# Altium schematic sheet BRAINS.SchDoc(BRAINS)
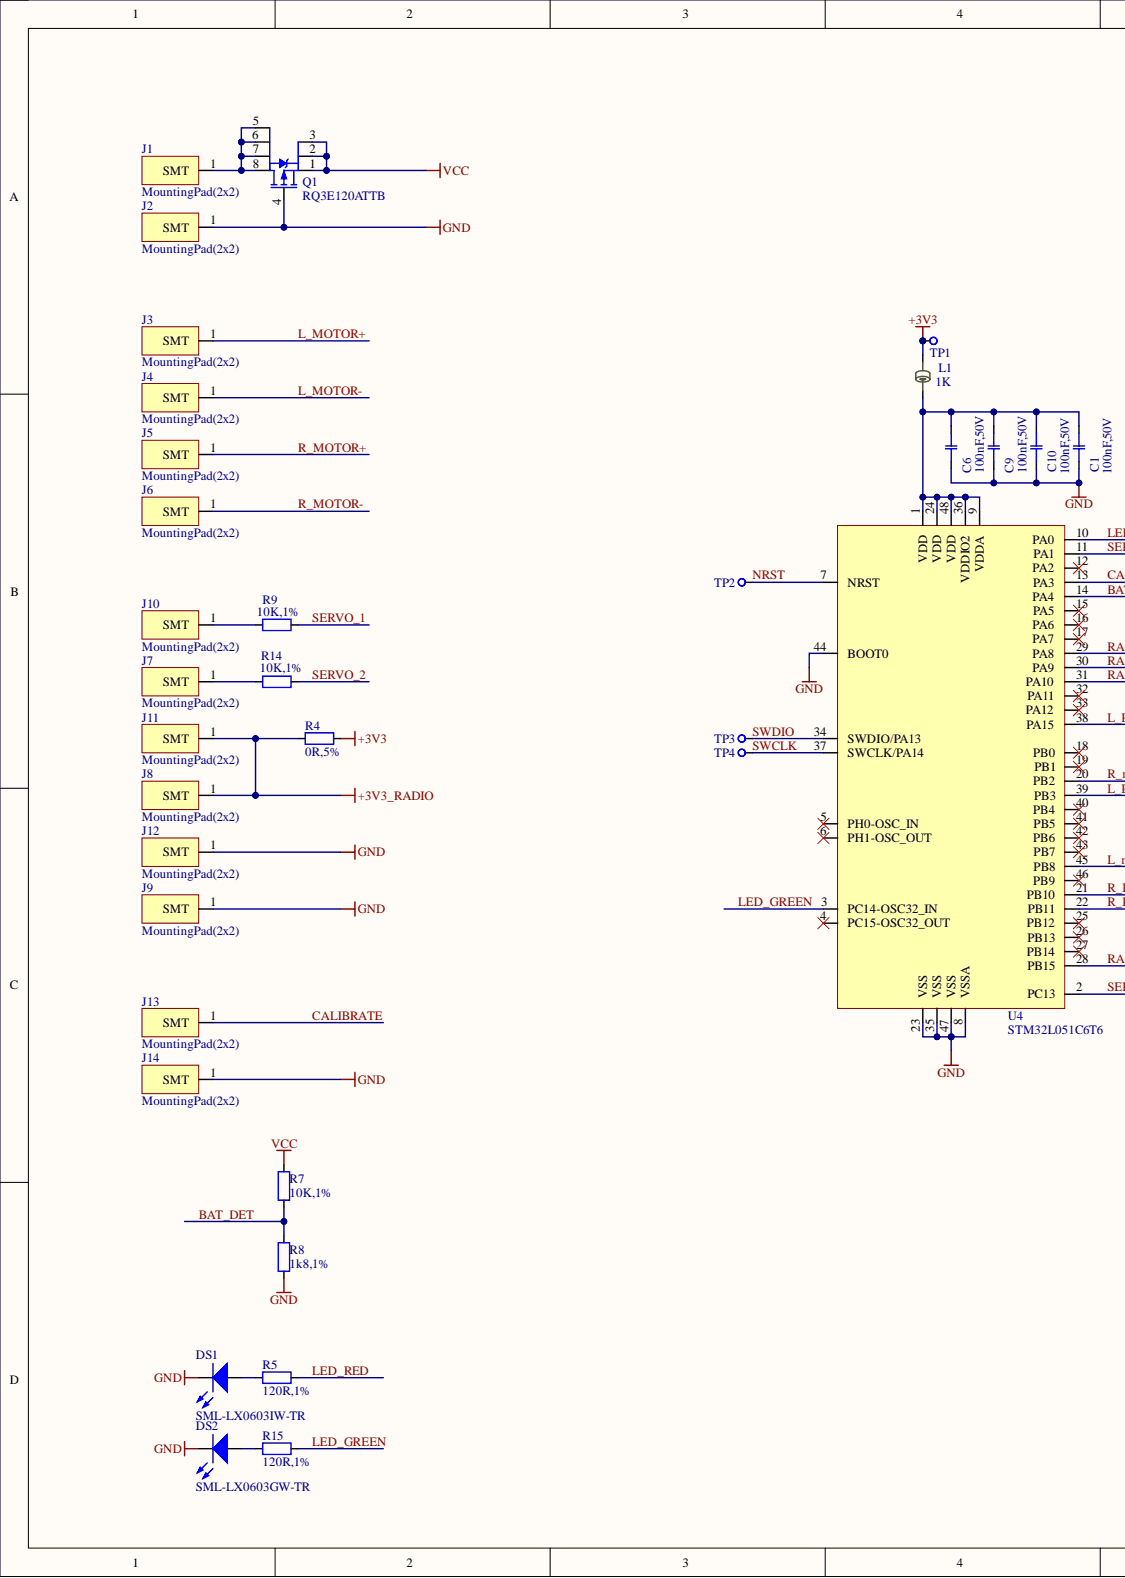
[diagram p0: BRAINS.SchDoc, left]
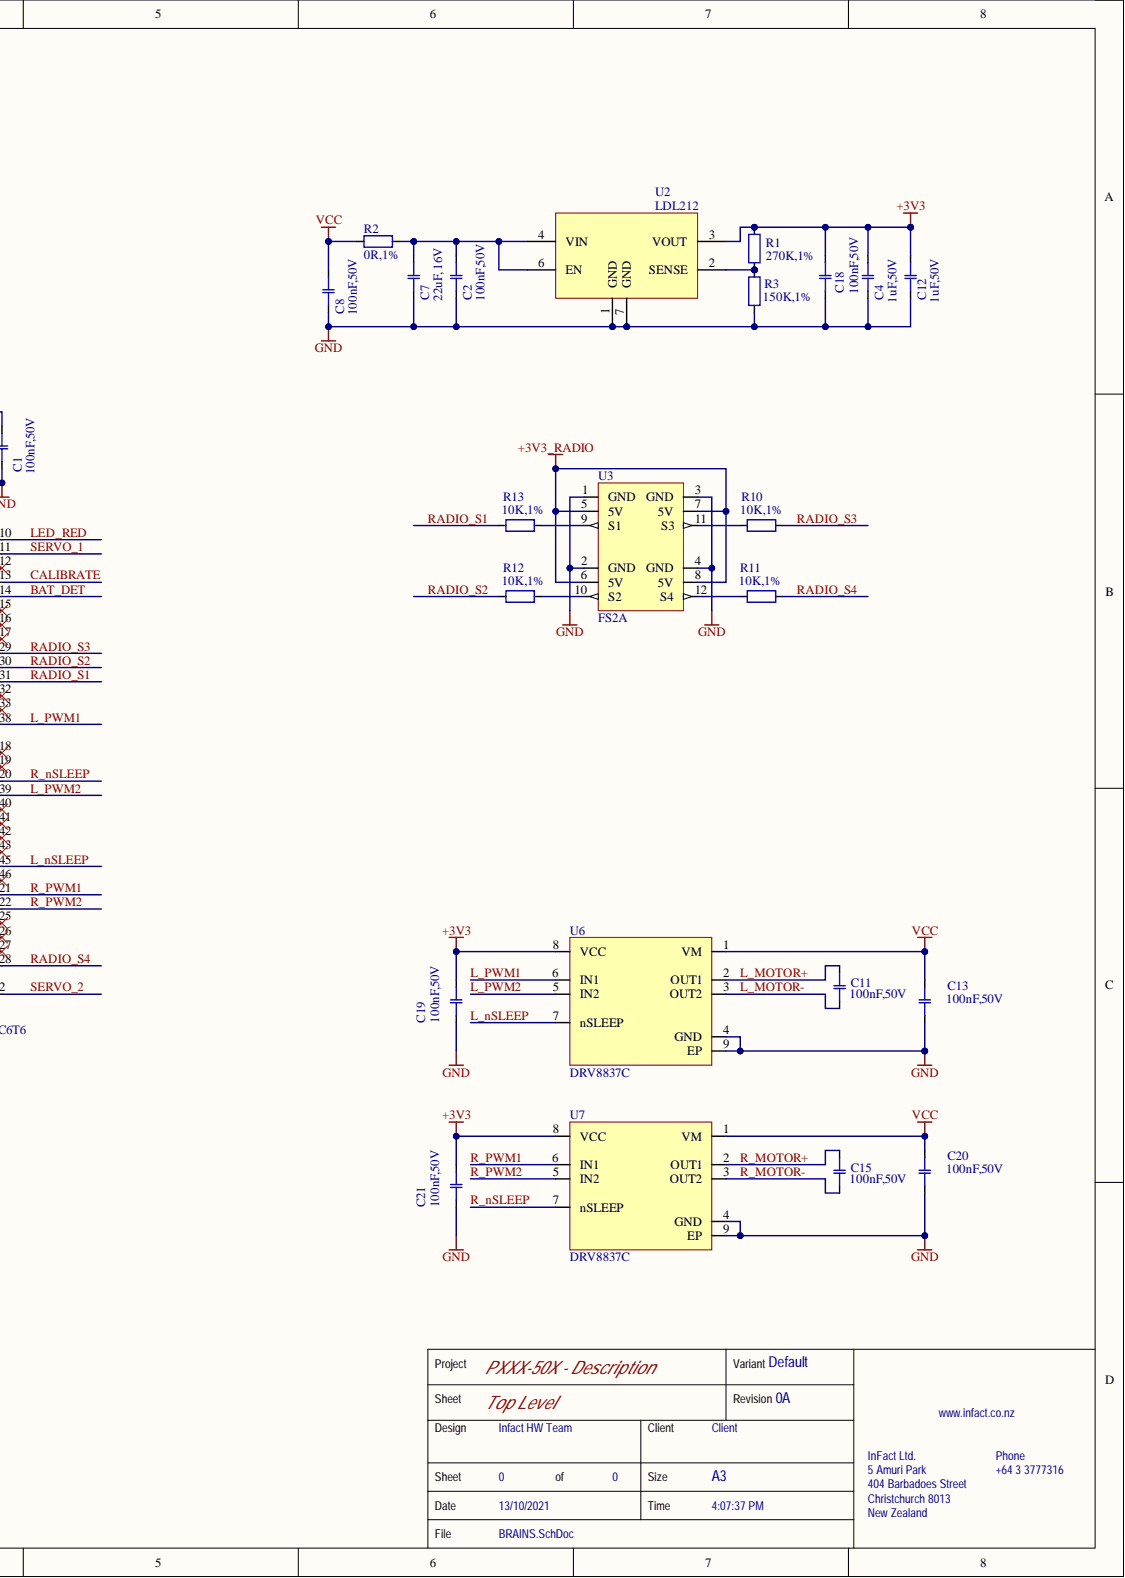
[diagram p1: BRAINS.SchDoc, right]

## Parts
C1  100nF,50V  [CAPC1005X05N_0402]
C2  100nF,50V  [CAPC1005X05N_0402]
C4  1uF,50V  [CAPC1005X05N_0402]
C6  100nF,50V  [CAPC1005X05N_0402]
C7  22uF, 16V  [CAPC2012X12N_0805]
C8  100nF,50V  [CAPC1005X05N_0402]
C9  100nF,50V  [CAPC1005X05N_0402]
C10  100nF,50V  [CAPC1005X05N_0402]
C11  100nF,50V  [CAPC1005X05N_0402]
C12  1uF,50V  [CAPC1005X05N_0402]
C13  100nF,50V  [CAPC1005X05N_0402]
C15  100nF,50V  [CAPC1005X05N_0402]
C18  100nF,50V  [CAPC1005X05N_0402]
C19  100nF,50V  [CAPC1005X05N_0402]
C20  100nF,50V  [CAPC1005X05N_0402]
C21  100nF,50V  [CAPC1005X05N_0402]
DS1  SML-LX0603IW-TR  [LED_SML-LX0603]
DS2  SML-LX0603GW-TR  [LED_SML-LX0603]
J1  MountingPad(2x2)  [MountingPad(2x2)]
J2  MountingPad(2x2)  [MountingPad(2x2)]
J3  MountingPad(2x2)  [MountingPad(2x2)]
J4  MountingPad(2x2)  [MountingPad(2x2)]
J5  MountingPad(2x2)  [MountingPad(2x2)]
J6  MountingPad(2x2)  [MountingPad(2x2)]
J7  MountingPad(2x2)  [MountingPad(2x2)]
J8  MountingPad(2x2)  [MountingPad(2x2)]
J9  MountingPad(2x2)  [MountingPad(2x2)]
J10  MountingPad(2x2)  [MountingPad(2x2)]
J11  MountingPad(2x2)  [MountingPad(2x2)]
J12  MountingPad(2x2)  [MountingPad(2x2)]
J13  MountingPad(2x2)  [MountingPad(2x2)]
J14  MountingPad(2x2)  [MountingPad(2x2)]
L1  1K  [INDC1005X04N_0402]
Q1  RQ3E120ATTB  [ROHM-HSMT8]
R1  270K,1%  [RESC1005X04N_0402]
R2  0R,1%  [RESC1005X04N_0402]
R3  150K,1%  [RESC1005X04N_0402]
R4  0R,5%  [RESC1608X06N_0603]
R5  120R,1%  [RESC1005X04N_0402]
R7  10K,1%  [RESC1005X04N_0402]
R8  1k8,1%  [RESC1005X04N_0402]
R9  10K,1%  [RESC1005X04N_0402]
R10  10K,1%  [RESC1005X04N_0402]
R11  10K,1%  [RESC1005X04N_0402]
R12  10K,1%  [RESC1005X04N_0402]
R13  10K,1%  [RESC1005X04N_0402]
R14  10K,1%  [RESC1005X04N_0402]
R15  120R,1%  [RESC1005X04N_0402]
TP1  TP_SMT  [TP_100]  (value not drawn)
TP2  TP_SMT  [TP_100]  (value not drawn)
TP3  TP_SMT  [TP_100]  (value not drawn)
TP4  TP_SMT  [TP_100]  (value not drawn)
U2  LDL212  [DFN50P200X200X80-8N_PWSON6]
U3  FS2A  [FS2A]
U4  STM32L051C6T6  [TSQFP50P900X900X160-48N_48LQFP]
U6  DRV8837C  [DFN50P200X200X80-8N]
U7  DRV8837C  [DFN50P200X200X80-8N]

## Nets
+3V3: C4.2 C12.2 C18.2 C19.2 C21.2 L1.2 R1.2 R4.2 TP1.1 U2.3(VOUT) U6.8(VCC) U7.8(VCC)
+3V3_RADIO: J8.1 J11.1 R4.1 U3.5(5V) U3.6(5V) U3.7(5V) U3.8(5V)
GND: C1.1 C2.1 C4.1 C6.1 C7.1 C8.1 C9.1 C10.1 C12.1 C13.1 C18.1 C19.1 C20.1 C21.1 DS1.1 DS2.1 J2.1 J9.1 J12.1 J14.1 Q1.4 R3.1 R8.2 U2.1(GND) U2.7(GND) U3.1(GND) U3.2(GND) U3.3(GND) U3.4(GND) U4.8(VSSA) U4.23(VSS) U4.35(VSS) U4.44(BOOT0) U4.47(VSS) U6.4(GND) U6.9(EP) U7.4(GND) U7.9(EP)
VCC: C8.2 C13.2 C20.2 Q1.1 Q1.2 Q1.3 R2.1 R7.1 U6.1(VM) U7.1(VM)
BAT_DET: R7.2 R8.1 U4.14(PA4)
CALIBRATE: J13.1 U4.13(PA3)
L_MOTOR+: C11.2 J3.1 U6.2(OUT1)
L_MOTOR-: C11.1 J4.1 U6.3(OUT2)
L_nSLEEP: U4.45(PB8) U6.7(nSLEEP)
L_PWM1: U4.38(PA15) U6.6(IN1)
L_PWM2: U4.39(PB3) U6.5(IN2)
LED_GREEN: R15.2 U4.3(PC14-OSC32_IN)
LED_RED: R5.2 U4.10(PA0)
NRST: TP2.1 U4.7(NRST)
R_MOTOR+: C15.2 J5.1 U7.2(OUT1)
R_MOTOR-: C15.1 J6.1 U7.3(OUT2)
R_nSLEEP: U4.20(PB2) U7.7(nSLEEP)
R_PWM1: U4.21(PB10) U7.6(IN1)
R_PWM2: U4.22(PB11) U7.5(IN2)
RADIO_S1: R13.2 U4.31(PA10)
RADIO_S2: R12.2 U4.30(PA9)
RADIO_S3: R10.1 U4.29(PA8)
RADIO_S4: R11.1 U4.28(PB15)
SERVO_1: R9.1 U4.11(PA1)
SERVO_2: R14.1 U4.2(PC13)
SWCLK: TP4.1 U4.37(SWCLK/PA14)
SWDIO: TP3.1 U4.34(SWDIO/PA13)
NetC1_2: C1.2 C6.2 C9.2 C10.2 L1.1 U4.1(VDD) U4.9(VDDA) U4.24(VDD) U4.36(VDDIO2) U4.48(VDD)
NetC2_2: C2.2 C7.2 R2.2 U2.4(VIN) U2.6(EN)
NetDS1_2: DS1.2 R5.1
NetDS2_2: DS2.2 R15.1
NetJ1_1: J1.1 Q1.5 Q1.6 Q1.7 Q1.8
NetJ7_1: J7.1 R14.2
NetJ10_1: J10.1 R9.2
NetR1_1: R1.1 R3.2 U2.2(SENSE)
NetR10_2: R10.2 U3.11(S3)
NetR11_2: R11.2 U3.12(S4)
NetR12_1: R12.1 U3.10(S2)
NetR13_1: R13.1 U3.9(S1)

Not connected: U4 4(PC15-OSC32_OUT) 5(PH0-OSC_IN) 6(PH1-OSC_OUT) 12(PA2) 15(PA5) 16(PA6) 17(PA7) 18(PB0) 19(PB1) 25(PB12) 26(PB13) 27(PB14) 32(PA11) 33(PA12) 40(PB4) 41(PB5) 42(PB6) 43(PB7) 46(PB9)

## Library data of the parts
C1 C2 C6 C8-C11 C13 C15 C18-C21 = CCG-104CE-G: CAP CER 100nF 50V X7R 0402; Manufacturer: Taiyo Yuden; Manufacturer Part Number: UMK105B7104KV-FR; Database Table Name: c_capacitor; Schematic Symbol: CAP-NP_1; Tolerance: 10%; Type: Ceramic; BWA PN: CCG-104CE-G; Capacitance: 100nF; Component Kind: Standard; Dielectric: X7R; Display_Name: 100nF,50V; Library Name: library.Dblib; Supplier 1: Digi-Key; Supplier Part Number 1: 587-3498-1-ND; Voltage: 50V
C4 C12 = CCG-106DH-H: CAP CER 1UF 50V X5R 0402; Manufacturer: Taiyo Yuden; Manufacturer Part Number: UMK105CBJ105MV-F; Database Table Name: c_capacitor; Schematic Symbol: CAP-NP_1; Tolerance: 20%; Type: Ceramic; BWA PN: CCG-106DH-H; Capacitance: 1uF; Component Kind: Standard; Dielectric: X5R; Display_Name: 1uF,50V; Library Name: library.Dblib; Supplier 1: Digi-Key; Supplier Part Number 1: 587-6278-1-ND; Voltage: 50V
C7 = CCI-226DE-G: CAP CER 22UF 16V X5R 0805; Manufacturer: Samsung Electro-Mechanics; Manufacturer Part Number: CL21A226KOQNNNE; Database Table Name: c_capacitor; Schematic Symbol: CAP-NP_1; Tolerance: 10%; Type: Ceramic; BWA PN: CCI-226DE-G; Capacitance: 22uF; Component Kind: Standard; Dielectric: X5R; Display_Name: 22uF, 16V; Library Name: library.Dblib; Supplier 1: Digi-Key; Supplier Part Number 1: 1276-6526-1-ND; Voltage: 16V
DS1 = LED-10056-A: LED 635NM RED DIFF 0603 SMD; Manufacturer: Lumex Opto/Components Inc; Axial Intensity: 14mcd; Database Table Name: ds_led; If(max): 20mA; Lens Style/Size: Rectangle, 1mmx0.8mm; Lens type: Diffused, White; Schematic Symbol: LED_1; Vf@I: 2V; Viewing Angle: 160deg; Vr(max): 5V; BWA PN: LED-10056-A; Colour: Red; Component Kind: Standard; Display_Name: SML-LX0603IW-TR; Library Name: library.Dblib; Manufacturer Part Number: SML-LX0603IW-TR; Supplier 1: Digi-Key; Supplier Part Number 1: 67-1548-1-ND; Wavelength: 635nm
DS2 = LED-10011-A: LED 565NM GRN DIFF 0603 SMD; Manufacturer: Lumex Opto/Components Inc; Axial Intensity: 18mcd; Colour: Green; Database Table Name: ds_led; If(max): 25mA; Lens Style/Size: Rectangle, 1mmx0.8mm; Lens type: Diffused, White; Schematic Symbol: LED_1; Vf@I: 2.2V; Viewing Angle: 160deg; Vr(max): 5V; BWA PN: LED-10011-A; Component Kind: Standard; Display_Name: SML-LX0603GW-TR; Library Name: library.Dblib; Manufacturer Part Number: SML-LX0603GW-TR; Supplier 1: Digi-Key; Supplier Part Number 1: 67-1549-1-ND; Wavelength: 565nm
L1 = IND-10140-A: FILTER CHIP 1000 OHM 250MA 0402; Manufacturer: Murata Electronics North America; Manufacturer Part Number: BLM15HG102SN1D; Database Table Name: l_inductor; DC Resistance: 1.1Ohm; Schematic Symbol: INDUCTOR_1; BWA PN: IND-10140-A; Component Kind: Standard; Current Rating: 250mA; Display_Name: 1K; Library Name: library.Dblib; Supplier 1: Digi-Key; Supplier Part Number 1: 490-3999-1-ND
Q1 = TRN-10125-A: MOSFET P-CH 30V 12A 8HSMT; Manufacturer: Rohm Semiconductor; Database Table Name: q_mosfet; Id(max): 12A (Ta); rds(on): 8mOhm @ 12A, 10V; Schematic Symbol: MOSFET-P_5; Type: P; Vgs(max): ±20V  ±20V  ±20V; Vth(max): 2.5V @ 1mA; BWA PN: TRN-10125-A; Component Kind: Standard; Display_Name: RQ3E120ATTB; Library Name: library.Dblib; Manufacturer Part Number: RQ3E120ATTB; Supplier 1: Digi-Key; Supplier Part Number 1: RQ3E120ATTBCT-ND; Vds(max): 30V
R1 = RSG-270K0-D: RES SMD 270K OHM 1% 1/16W 0402; Manufacturer: Yageo; Manufacturer Part Number: RC0402FR-07270KL; Database Table Name: r_resistor; Power: 0.063W; Schematic Symbol: RESISTOR_1; BWA PN: RSG-270K0-D; Component Kind: Standard; Display_Name: 270K,1%; Library Name: library.Dblib; Resistance: 270K; Supplier 1: Digi-Key; Supplier Part Number 1: 311-270KLRCT-ND; Tolerance: 1%; Type: Chip
R2 = RSG-0R000-F: RES 0R OHM 1/16W 5% 0402 SMD; Manufacturer: Yageo; Manufacturer Part Number: RC0402JR-070RL; Database Table Name: r_resistor; Power: 0.0625W; Schematic Symbol: RESISTOR_1; BWA PN: RSG-0R000-F; Component Kind: Standard; Display_Name: 0R,1%; Library Name: library.Dblib; Resistance: 0R; Supplier 1: Digi-Key; Supplier Part Number 1: 311-0.0JRCT-ND; Tolerance: 5%; Type: Chip
R3 = RSG-150K0-D: RES SMD 150K OHM 1% 1/16W 0402; Manufacturer: Yageo; Manufacturer Part Number: RC0402FR-07150KL; Database Table Name: r_resistor; Power: 0.063W; Schematic Symbol: RESISTOR_1; BWA PN: RSG-150K0-D; Component Kind: Standard; Display_Name: 150K,1%; Library Name: library.Dblib; Resistance: 150K; Supplier 1: Digi-Key; Supplier Part Number 1: 311-150KLRCT-ND; Tolerance: 1%; Type: Chip
R4 = RSH-0R000-F: RES 0.0 OHM 1/10W 0603 SMD; Manufacturer: Yageo; Manufacturer Part Number: RC0603JR-070RL; Database Table Name: r_resistor; Power: 0.1W; Schematic Symbol: RESISTOR_1; BWA PN: RSH-0R000-F; Component Kind: Standard; Display_Name: 0R,5%; Library Name: library.Dblib; Resistance: 0R; Supplier 1: Digi-Key; Supplier Part Number 1: 311-0.0GRCT-ND; Tolerance: 5%; Type: Chip
R5 R15 = RSG-120R0-D: RES 120 OHM 1/16W 0402 SMD; Manufacturer: Yageo; Manufacturer Part Number: RC0402FR-07120RL; Database Table Name: r_resistor; Power: 0.0625W; Schematic Symbol: RESISTOR_1; BWA PN: RSG-120R0-D; Component Kind: Standard; Display_Name: 120R,1%; Library Name: library.Dblib; Resistance: 120R; Supplier 1: Digi-Key; Supplier Part Number 1: 311-120LRCT-ND; Tolerance: 1%; Type: Chip
R7 R9-R14 = RSG-10K00-D: RES 10K OHM 1/16W 1% 0402 SMD; Manufacturer: Yageo; Manufacturer Part Number: RC0402FR-0710KL; Database Table Name: r_resistor; Power: 0.0625W; Schematic Symbol: RESISTOR_1; BWA PN: RSG-10K00-D; Component Kind: Standard; Display_Name: 10K,1%; Library Name: library.Dblib; Resistance: 10K; Supplier 1: Digi-Key; Supplier Part Number 1: 311-10.0KLRCT-ND; Tolerance: 1%; Type: Chip
R8 = RSG-1K800-D: RES 1k8 0.0625W 1% 0402 SMD; Manufacturer: Vishay Dale; Manufacturer Part Number: CRCW04021K80FKED; Database Table Name: r_resistor; Schematic Symbol: RESISTOR_1; BWA PN: RSG-1K800-D; Component Kind: Standard; Display_Name: 1k8,1%; Library Name: library.Dblib; Power: 0.0625W; Resistance: 1k8; Supplier 1: Digi-Key; Supplier Part Number 1: 541-1.80KLCT-ND; Tolerance: 1%; Type: Chip
TP1-TP4 = NONPART-002: Test Point - SMT; Database Table Name: n_non_part; Schematic Symbol: TP_1; BWA PN: NONPART-002; Component Kind: Standard; Display_Name: TP_SMT; Library Name: library.Dblib
U2 = ICT-10917-A: IC REG LINEAR POS ADJ 1.2A 6DFN; Manufacturer: STMicroelectronics; Manufacturer Part Number: LDL212PUR; Database Table Name: u_integrated_circuit; Schematic Symbol: LDL212PU50R; Temp Range: -40°C ~ 125°C (TJ); BWA PN: ICT-10917-A; Component Kind: Standard; Display_Name: LDL212; Library Name: library.Dblib; Supplier 1: Digi-Key; Supplier Part Number 1: 497-16566-1-ND
U4 = ICT-10938-A: IC MCU 32BIT 32KB FLASH 48LQFP; Manufacturer: STMicroelectronics; Database Table Name: u_integrated_circuit; Schematic Symbol: STM32L051C6T6_48LQFP; Temp Range: -40°C ~ 85°C (TA); BWA PN: ICT-10938-A; Component Kind: Standard; Display_Name: STM32L051C6T6; Library Name: library.Dblib; Manufacturer Part Number: STM32L051C6T6; Supplier 1: Digi-Key; Supplier Part Number 1: 497-15202-ND
U6 U7 = ICT-10892-A: IC HALF-BRIDGE DRVR PWM 8WSON; Manufacturer: Texas Instruments; Manufacturer Part Number: DRV8837CDSGR; Database Table Name: u_integrated_circuit; Temp Range: -40°C ~ 85°C; BWA PN: ICT-10892-A; Component Kind: Standard; Display_Name: DRV8837C; Library Name: library.Dblib; Schematic Symbol: DRV8837C; Supplier 1: Digi-Key; Supplier Part Number 1: 296-47205-1-ND
J1-J14 = MountingPad(2x2): Component Kind: Standard; Library Name: Schlib1.SchLib
U3 = FS2A: Component Kind: Standard; Library Name: Schlib1.SchLib
